# Altium schematic sheet [8]-MODEM_MAIN.SchDoc([8]-MODEM_MAIN)
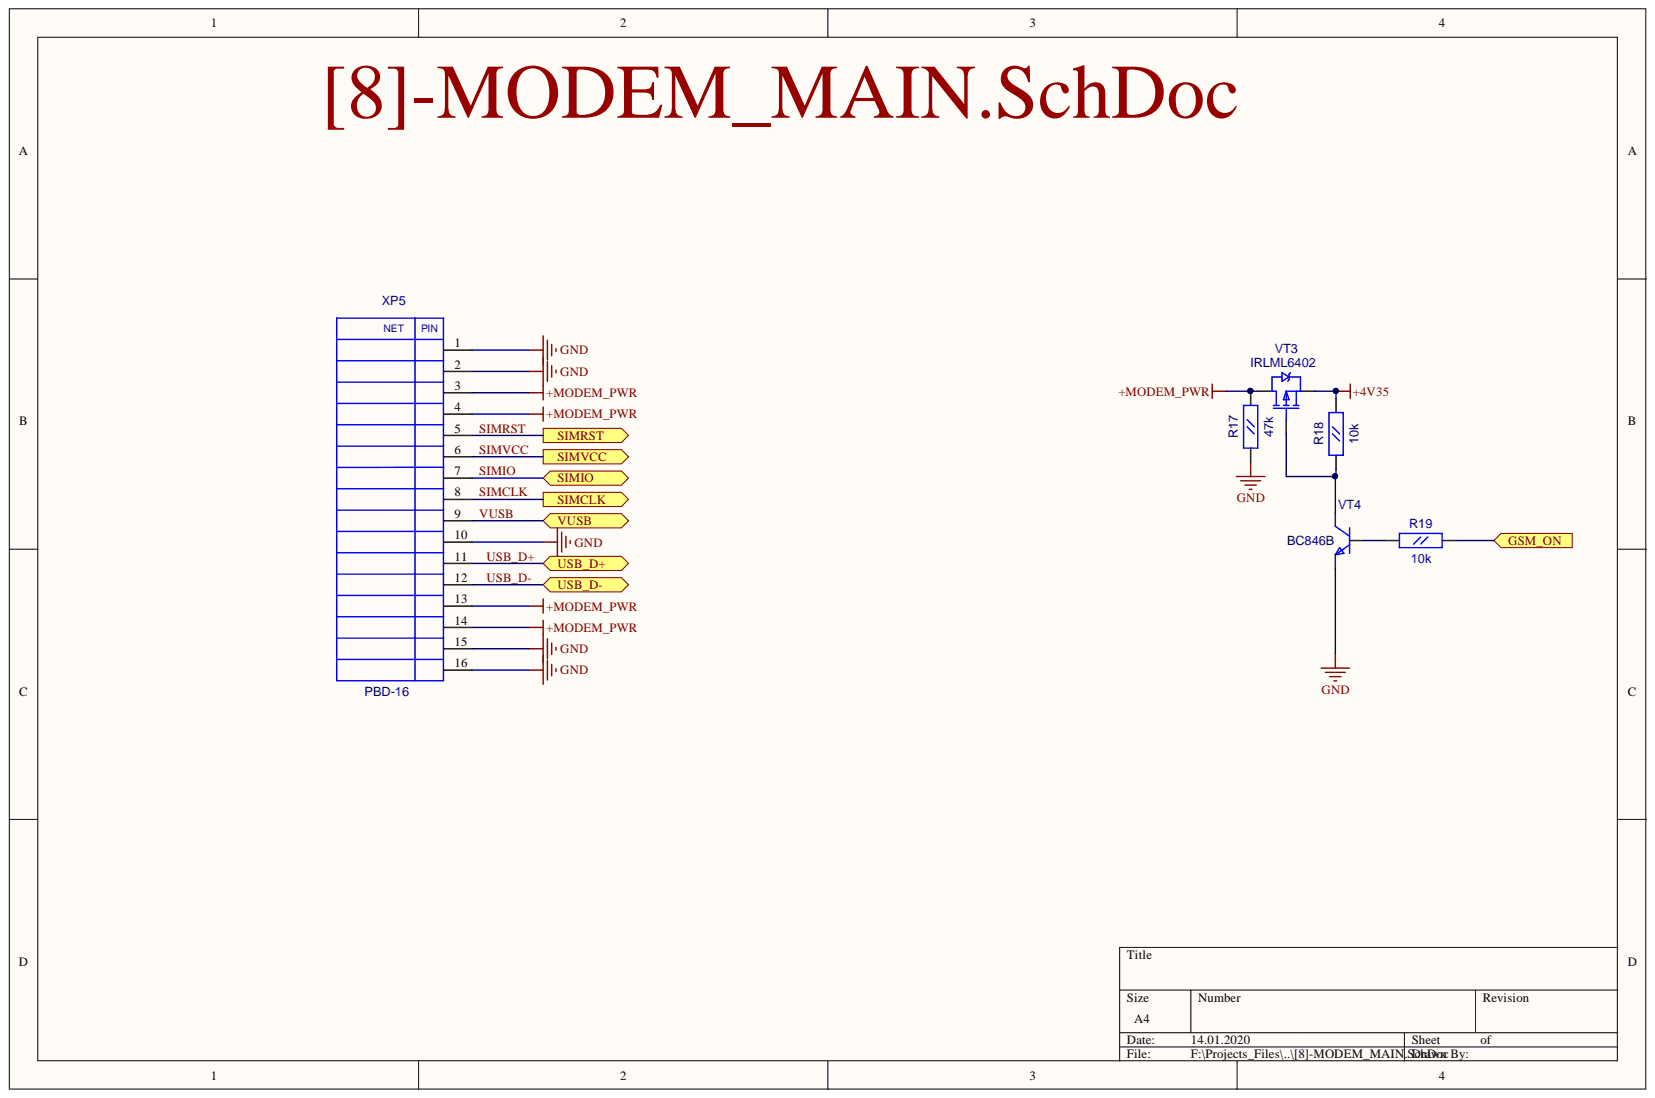
[diagram p0: [8]-MODEM_MAIN.SchDoc]

## Parts
R17  47k  [RC0603]
R18  10k  [RC0603]
R19  10k  [RC0603]
VT3  IRLML6402  [SOT-23(123)]
VT4  BC846B  [SOT-23(123)]
XP5  PBD-16  [PBD16]

## Ports
GSM_ON, SIMCLK, SIMIO, SIMRST, SIMVCC, USB_D+, USB_D-, VUSB

## Nets
+4V35: R18.2 VT3.2
+MODEM_PWR: R17.2 VT3.3 XP5.3 XP5.4 XP5.13 XP5.14
GND: R17.1 VT4.2 XP5.1 XP5.2 XP5.10 XP5.15 XP5.16
GSM_ON: R19.2 ; port GSM_ON
SIMCLK: XP5.8 ; port SIMCLK
SIMIO: XP5.7 ; port SIMIO
SIMRST: XP5.5 ; port SIMRST
SIMVCC: XP5.6 ; port SIMVCC
USB_D+: XP5.11 ; port USB_D+
USB_D-: XP5.12 ; port USB_D-
VUSB: XP5.9 ; port VUSB
NetR18_1: R18.1 VT3.1 VT4.3
NetR19_1: R19.1 VT4.1

## Library data of the parts
R17-R19 = RC0603: Description: RC0603; Component Kind: Standard; Library Name: gprs_modem_2.SchLib
  R17: Value: 47k
  R18 R19: Value: 10k
VT3 = IRLML6402: HEXFET Power MOSFET; OriginalName: IRLML5103; ComponentField7: Published 8-Jun-2000; Value: IRLML6402; Component Kind: Standard; Library Name: gprs_modem_2.SchLib
VT4 = BC846BLT1: ComponentField7: Published 29-Sep-2000; Value: BC846B; Simulation: BC846BLT1; OriginalName: BC846BLT1; Description: BC846BLT1; Component Kind: Standard; Library Name: gprs_modem_2.SchLib
XP5 = PBD-16: Description: PBD-16; Component Kind: Standard; Library Name: fizik_lib.SchLib
